# Altium schematic sheet p05_HMI.SchDoc
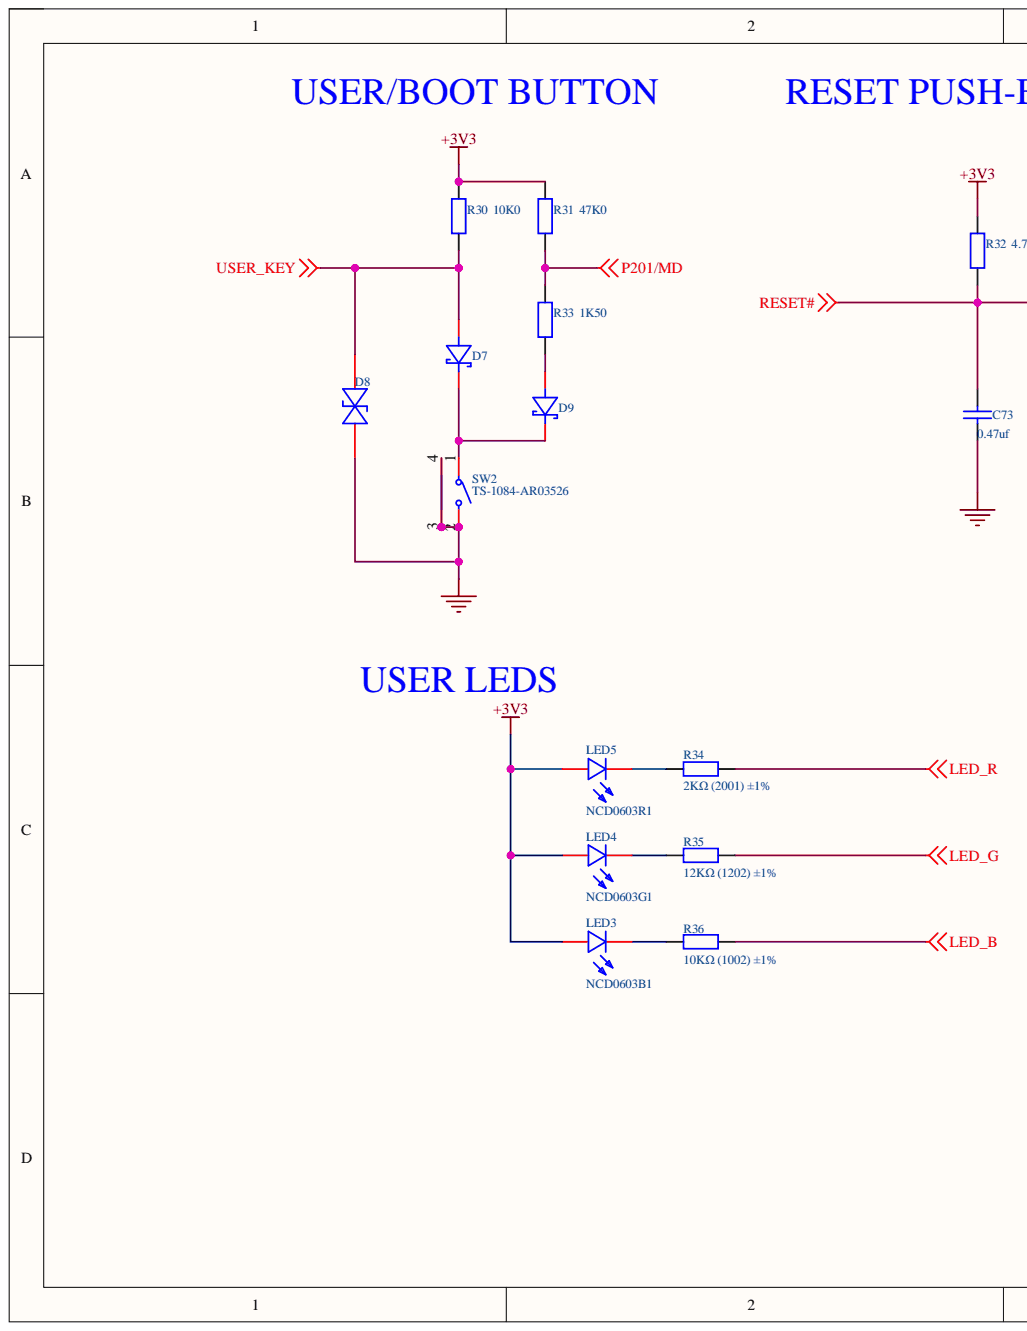
[diagram p0: p05_HMI.SchDoc, left]
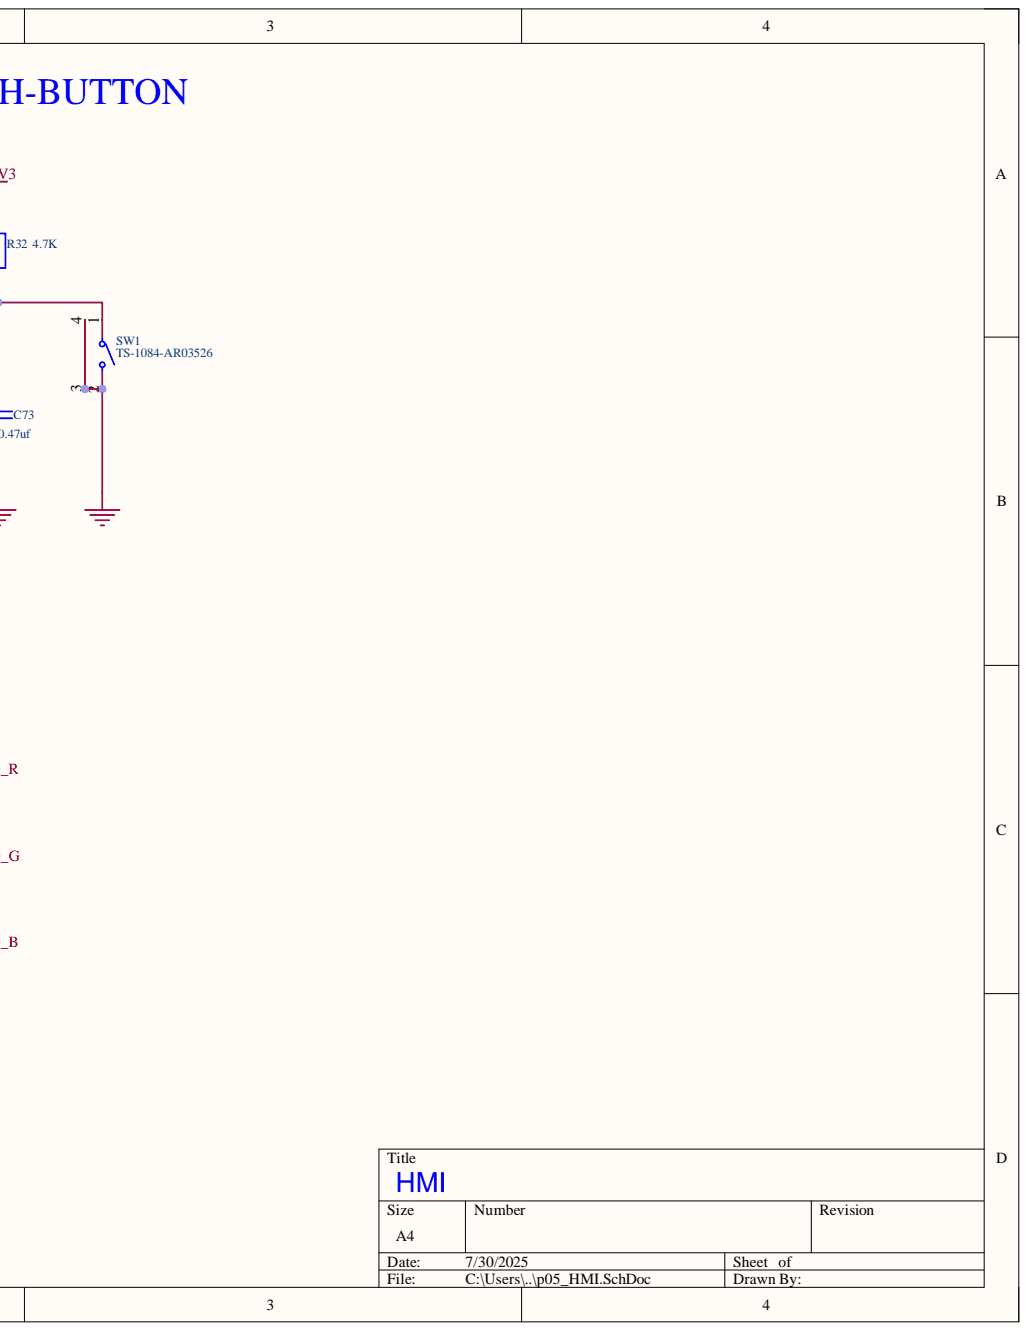
[diagram p1: p05_HMI.SchDoc, right]

## Parts
C73  0.47uf  [C0402]
D7  1N4148WS  [SOD-323_L1.7-W1.3-LS2.5-RD-1]  (value not drawn)
D8  TPESD0201G05V  [D0201-BI]  (value not drawn)
D9  1N4148WS  [SOD-323_L1.7-W1.3-LS2.5-RD-1]  (value not drawn)
LED3  NCD0603B1  [LED0603-RD]
LED4  NCD0603G1  [LED0603-RD]
LED5  NCD0603R1  [LED0603-RD]
R30  10K0  [R0402]
R31  47K0  [R0402]
R32  4.7K  [R0402]
R33  1K50  [R0402]
R34  2KΩ (2001) ±1%  [R0402]
R35  12KΩ (1202) ±1%  [R0402]
R36  10KΩ (1002) ±1%  [R0402]
SW1  TS-1084-AR03526  [SW-SMD_TS-1084-AR03526]
SW2  TS-1084-AR03526  [SW-SMD_TS-1084-AR03526]

## Nets
+3V3: LED3.2 LED4.2 LED5.2 R30.2 R31.2 R32.2
GND: C73.1 D8.1 SW1.2 SW1.3 SW1.4 SW2.2 SW2.3 SW2.4
LED_B: R36.2
LED_G: R35.2
LED_R: R34.2
P201/MD: R31.1 R33.2
RESET#: C73.2 R32.1 SW1.1
USER_KEY: D7.2 D8.2 R30.1
NetD7_1: D7.1 D9.1 SW2.1
NetD9_2: D9.2 R33.1
NetLED3_1: LED3.1 R36.1
NetLED4_1: LED4.1 R35.1
NetLED5_1: LED5.1 R34.1

## Library data of the parts
C73 = CAP-000114-01: Ceramic Chip Capacitor 0402 0.47uF 10V; Manufacturer: Samsung; Manufacturer Part Number 1: CL05A474KP5NNNC; Dialectric: X5R; Notes: ANY EQUIVALENT; Tolerance: 10%; Capacitance: 0.47uF; ClassName: Reset; Component Kind: Standard; Library Name: Capacitors.IntLib; Manufacturer 1: Samsung; Mfg Part Number: CL05A474KP5NNNC; Package: 0402; Supplier 1: Digi-Key; Supplier Part Number 1: 1276-1173-1-ND; Voltage: 10V
D7 D9 = 1N4148WS: 100V,150mA，开关二极管; Manufacturer: 宏嘉诚; Add into BOM: yes; Average Rectified Current (Io): 350mA; Device: SD103AWS_C7420360; Diode Configuration: 独立式; Forward Voltage (Vf@If): 370mV@20mA; Peak forward surge current: 1.5A; Reverse Leakage Current (Ir): 5uA@40V; Reverse Voltage (Vr): 40V; Component Kind: Standard; Convert to PCB: yes; Datasheet: https://atta.szlcsc.com/upload/public/pdf/source/20230828/EB98D42952FEC013AACB2F62A2475546.pdf; JLCPCB Part Class: Extended Part; LCSC Part Name: 电压:40V 电流:350mA; Library Name: ra8p1_v0.2.SCHLIB; Manufacturer Part: 1N4148WS; PCB3D: SOD-323_L1.7-W1.3-LS2.6-RD-1; Supplier: LCSC; Supplier Footprint: SOD-323; Supplier Part: C7420319; Symbol: SD103AWS_C7420360
D8 = TPESD0201G05V: TVS,0201,Vdc=5V，Vc=40V; Manufacturer: TECH PUBLIC(台舟); Add into BOM: yes; Type: ESD; Component Kind: Standard; Convert to PCB: yes; Datasheet: https://atta.szlcsc.com/upload/public/pdf/source/20231208/AB2619EB68EB5FE4BD54B2390A15C9FE.pdf; Device: TPESD0201G05V; JLCPCB Part Class: Extended Part; LCSC Part Name: TPESD0201G05V; Library Name: ra8p1_v0.1.SCHLIB; Manufacturer Part: TPESD0201G05V; PCB3D: D0201_L0.6-W0.3-H0.3; Supplier: LCSC; Supplier Footprint: 0201; Supplier Part: C558457; Symbol: TPESD0201G05V
LED3 = LED0603BLUE: BLUE,0603; Manufacturer: 国星光电; Manufacturer Part: NCD0603R1; Add into BOM: yes; Device: NCD0603R1_C84263; Diode Configuration: 独立二极管; Forward Current: 25mA; Illumination Color: 红色; Luminous Intensity: 80mcd~220mcd; Power(Watts): 65mW; Symbol: FC-DA1608HRK-620D; Viewing Angle: 130°; Voltage - Forward(Vf): 1.6V~2.6V; Wavelength - Dominant: 615nm~630nm; Color Temperature: -; Component Kind: Standard; Convert to PCB: yes; Datasheet: https://atta.szlcsc.com/upload/public/pdf/source/20200820/C84263_DABC6A75E58F576973B407FDBA283D60.pdf; JLCPCB Part Class: Extended Part; Lamp Holder Type: -; LCSC Part Name: NCD0603R1; Lens Color: -; Library Name: ra8p1_v0.1.SCHLIB; Mounting Sytle: -; Operating Temperature: -30℃~+85℃; PCB3D: LED0603-RD_RED; Peak Wavelength: -; Supplier: LCSC; Supplier Footprint: 0603; Supplier Part: C84263
LED4 = LED0603GREEN: GREEN,0603; Manufacturer: 国星光电; Manufacturer Part: NCD0603R1; Add into BOM: yes; Device: NCD0603R1_C84263; Diode Configuration: 独立二极管; Forward Current: 25mA; Illumination Color: 红色; Luminous Intensity: 80mcd~220mcd; Power(Watts): 65mW; Symbol: FC-DA1608HRK-620D; Viewing Angle: 130°; Voltage - Forward(Vf): 1.6V~2.6V; Wavelength - Dominant: 615nm~630nm; Color Temperature: -; Component Kind: Standard; Convert to PCB: yes; Datasheet: https://atta.szlcsc.com/upload/public/pdf/source/20200820/C84263_DABC6A75E58F576973B407FDBA283D60.pdf; JLCPCB Part Class: Extended Part; Lamp Holder Type: -; LCSC Part Name: NCD0603R1; Lens Color: -; Library Name: ra8p1_v0.1.SCHLIB; Mounting Sytle: -; Operating Temperature: -30℃~+85℃; PCB3D: LED0603-RD_RED; Peak Wavelength: -; Supplier: LCSC; Supplier Footprint: 0603; Supplier Part: C84263
LED5 = LED0603RED: RED,0603; Manufacturer: 国星光电; Add into BOM: yes; Device: NCD0603R1_C84263; Diode Configuration: 独立二极管; Forward Current: 25mA; Illumination Color: 红色; Luminous Intensity: 80mcd~220mcd; Power(Watts): 65mW; Symbol: FC-DA1608HRK-620D; Viewing Angle: 130°; Voltage - Forward(Vf): 1.6V~2.6V; Wavelength - Dominant: 615nm~630nm; Color Temperature: -; Component Kind: Standard; Convert to PCB: yes; Datasheet: https://atta.szlcsc.com/upload/public/pdf/source/20200820/C84263_DABC6A75E58F576973B407FDBA283D60.pdf; JLCPCB Part Class: Extended Part; Lamp Holder Type: -; LCSC Part Name: NCD0603R1; Lens Color: -; Library Name: ra8p1_v0.1.SCHLIB; Manufacturer Part: NCD0603R1; Mounting Sytle: -; Operating Temperature: -30℃~+85℃; PCB3D: LED0603-RD_RED; Peak Wavelength: -; Supplier: LCSC; Supplier Footprint: 0603; Supplier Part: C84263
R30 = RES-100099-01: 贴片电阻，10K; Manufacturer: Yageo; Manufacturer Part Number 1: RC0402FR-0710KP; Notes: ANY EQUIVALENT; Power: 1/16W; Tolerance: 1%; Component Kind: Standard; ComponentLink1Description: New link; ComponentLink1URL: http://www.szlcsc.com/product/details_26487.html; Library Name: Resistors.IntLib; Manufacturer 1: Yageo; Manufacturer 2: Samsung Electro-Mechanics; Manufacturer 3: Stackpole Electronics Inc.; Manufacturer Part Number 2: RC1005F103CS; Manufacturer Part Number 3: RMCF0402FT10K0; Mfg: Yageo; Mfg Part Number: RC0402FR-0710KP; Package: 0402; PN: RC0402FR-0710KP; Resistance: 10K0; Supplier 1: Digi-Key; Supplier 2: Digi-Key; Supplier 3: Digi-Key; Supplier Part Number 1: YAG1249CT-ND; Supplier Part Number 2: 1276-3431-1-ND; Supplier Part Number 3: RMCF0402FT10K0CT-ND
R31 = RES-000173-01: Chip Resistor Thick Film 0402 47K0 1% 1/10W; Manufacturer: Panasonic; Manufacturer Part Number 1: ERJ-2RKF4702X; Notes: ANY EQUIVALENT; ClassName: Boot-Mode; Component Kind: Standard; Library Name: Resistors.IntLib; Manufacturer 1: Panasonic; Mfg Part Number: ERJ-2RKF4702X; Package: 0402; Power: 1/10W; Resistance: 47K0; Supplier 1: Digi-Key; Supplier Part Number 1: P47.0KLCT-ND; Tolerance: 1%
R32 = RES-100119-01: Chip Resistor Thick Film 0402 4.7K ohm 1% 1/16W; Legacy Part Number: 150-00000094; Manufacturer: Yageo; Manufacturer Part Number 1: RC0402FR-074K7L; Notes: ANY EQUIVALENT; Resistance: 4K7; ClassName: Reset; Component Kind: Standard; Library Name: Resistors.IntLib; Manufacturer 1: Yageo; Mfg: Yageo; Mfg P/N: RC0402FR-074K7L; Mfg Part Number: RC0402FR-074K7L; Package: 0402; PN: RC0402FR-074K7L; Power: 1/16W; Supplier 1: Future Electronics; Supplier 2: Digi-Key; Supplier 3: Mouser; Supplier 4: Farnell; Supplier Part Number 1: 3795218; Supplier Part Number 2: 311-4.7KLRCT-ND; Supplier Part Number 3: 603-RC0402FR-074K7L; Supplier Part Number 4: 9239316; Tolerance: 1%
R33 = RES-000140-01: Chip Resistor Thick Film 0402 1K50 0.5% 1/16W; Manufacturer: Panasonic; Manufacturer Part Number 1: ERA-2AED152X; Notes: ANY EQUIVALENT; ClassName: Boot-Mode; Component Kind: Standard; Library Name: Resistors.IntLib; Manufacturer 1: Panasonic; Mfg Part Number: ERA-2AED152X; Package: 0402; Power: 1/16W; Resistance: 1K50; Supplier 1: Digi-Key; Supplier Part Number 1: P1.5KDECT-ND; Tolerance: 0.5%
R34 = 0402 2KΩ (2001) 1%: 贴片电阻; Component Kind: Standard; ComponentLink1Description: 供应商链接; ComponentLink1URL: http://www.szlcsc.com/product/details_4516.html; Library Name: RES.SchLib; Package: 0402; Supplier: LC; SuppliersPartNumber: C4109
R35 = 0402 12KΩ (1202) 1%: 贴片电阻; Component Kind: Standard; ComponentLink1Description: 供应商链接; ComponentLink1URL: http://www.szlcsc.com/product/details_26495.html; Library Name: RES.SchLib; Package: 0402; Supplier: LC; SuppliersPartNumber: C25752
R36 = 0402 10KΩ (1002) 1%: 贴片电阻，10K; Component Kind: Standard; ComponentLink1Description: 供应商链接; ComponentLink1URL: http://www.szlcsc.com/product/details_26487.html; Library Name: RES.SchLib; Package: 0402; Supplier: LC; SuppliersPartNumber: C25744
SW1 SW2 = TS-1084-AR03526: 按键侧贴，2.6N; Manufacturer: XUNPU(讯普); Actuator Style: 圆形按钮; Actuator/Cap Color: 白色; Add into BOM: yes; Circuit: 单刀单掷; Contact Current: 50mA; Insulation Resistance: 100MΩ; Mechanical Life: 10万次; Operating Force: 260gf; Pin Style: SMD接片; Switch Height: 3.5mm; Switch Length: 4.5mm; Switch Width: 3.4mm; Voltage Rating (DC): 12V; Component Kind: Standard; Convert to PCB: yes; Datasheet: https://atta.szlcsc.com/upload/public/pdf/source/20200810/C720495_E832ACC0F01765863927299F269536F0.pdf; Device: TS-1084-AR03526; JLCPCB Part Class: Extended Part; LCSC Part Name: 4.5*3.4*3.5mm 卧贴 轻触开关; Library Name: ra8p1_v0.2.SCHLIB; Manufacturer Part: TS-1084-AR03526; Mounting Style: 卧贴; Operating Temperature: -30℃~+80℃; PCB3D: SW-SMD_TS-1084-AR03526; Supplier: LCSC; Supplier Footprint: SMD; Supplier Part: C720495; Symbol: TS-1084-AR03526
